# Altium schematic sheet schematic3.SchDoc
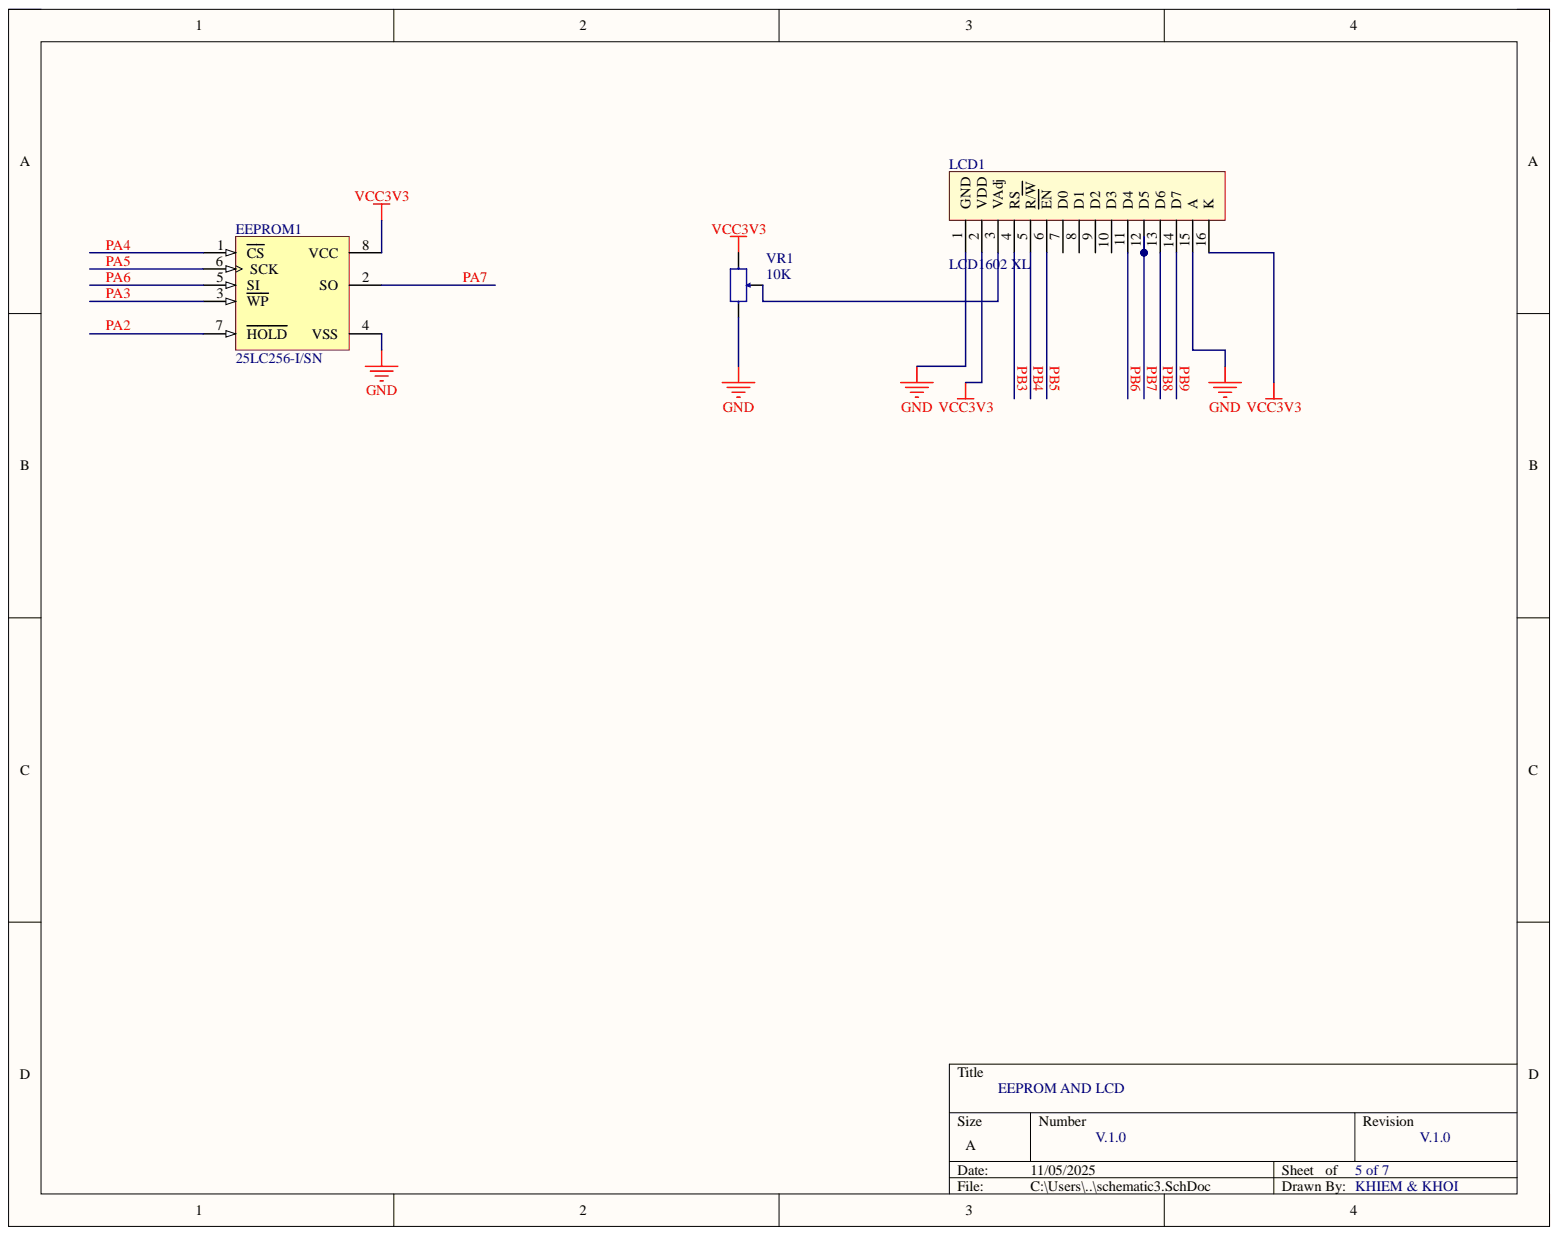
[diagram p0: schematic3.SchDoc]

## Parts
EEPROM1  25LC256-I/SN  [SOIC-SN8_L]
LCD1  LCD1602 XL  [LCD1602 with Jump]
VR1  10K  [RVAR10K]

## Nets
GND: EEPROM1.4(VSS) LCD1.1(GND) LCD1.15(A) VR1.3
VCC3V3: EEPROM1.8(VCC) LCD1.2(VDD) LCD1.16(K) VR1.1
PA2: EEPROM1.7(HOLD)
PA3: EEPROM1.3(WP)
PA4: EEPROM1.1(CS)
PA5: EEPROM1.6(SCK)
PA6: EEPROM1.5(SI)
PA7: EEPROM1.2(SO)
PB3: LCD1.4(RS)
PB4: LCD1.5(R/W)
PB5: LCD1.6(EN)
PB6: LCD1.11(D4)
PB7: LCD1.12(D5)
PB8: LCD1.13(D6)
PB9: LCD1.14(D7)
NetLCD1_3: LCD1.3(VAdj) VR1.2

Not connected: LCD1 7(D0) 8(D1) 9(D2) 10(D3)

## Library data of the parts
EEPROM1 = CMP-0165-00113-1: 256K SPI Bus Serial EEPROM, 8-Pin SOIC, Industrial Temperature; Code_IPC: SOIC127P600-8; Code_JEDEC: MS-012; Component Kind: Standard; DatasheetVersion: revF, May-2007; Library Name: Altium Content Vault; PackageDescription: 8-Lead Plastic Small Outline (SN) - Narrow, 3.90mm Body [SOIC]; PackageReference: SOIC-SN8; PackageVersion: revBB, Aug-2009
LCD1 = LCD1602 XL: LCD 1602 Xanh Lá; CHIP: HD44780; Ma_Hang: 67HZ; NCC: NSHOP; Ten: Màn hình LCD 1602 Xanh Lá; Component Kind: Standard; Library Name: Altium_Lib_by_KhueNguyenCreator.IntLib
VR1 = VR: Var Resistor; Value: VR 10K; Component Kind: Standard; Library Name: syhaunguyen.IntLib
